# Altium schematic sheet CC2650RC_4MOD1106_periph_part_rev1_2.SchDoc(CC2650RC_4MOD1106_periph_part_rev1_2)
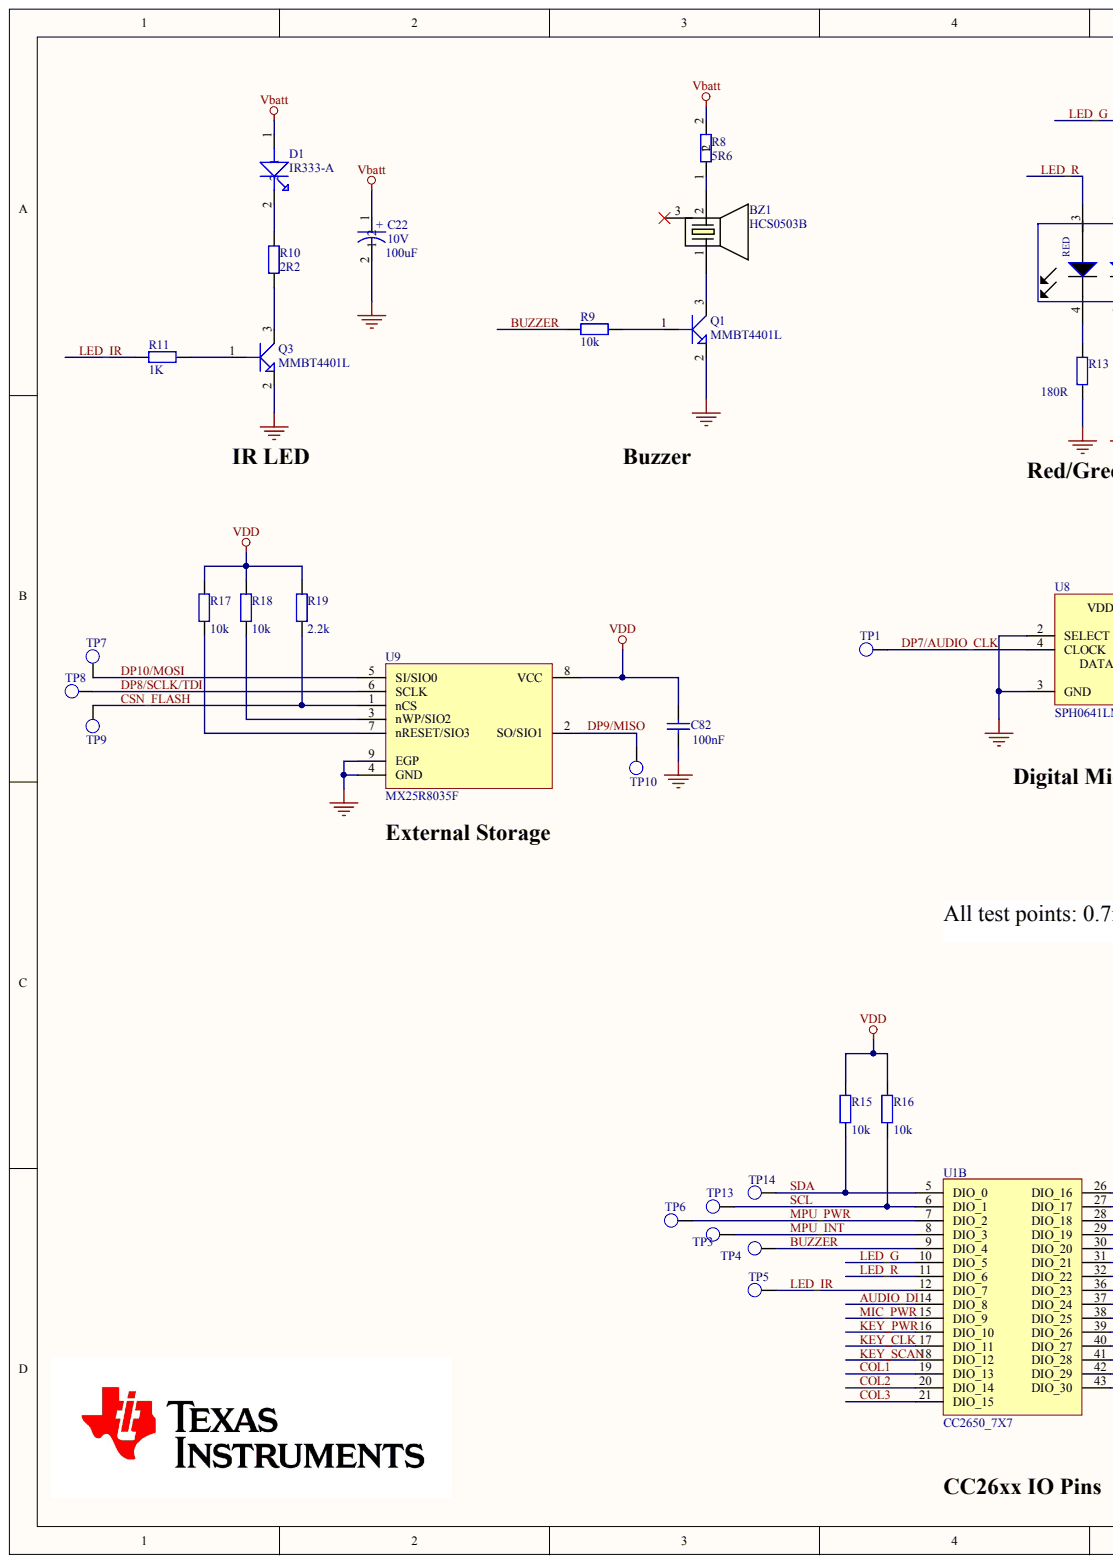
[diagram p0: CC2650RC_4MOD1106_periph_part_rev1_2.SchDoc, left]
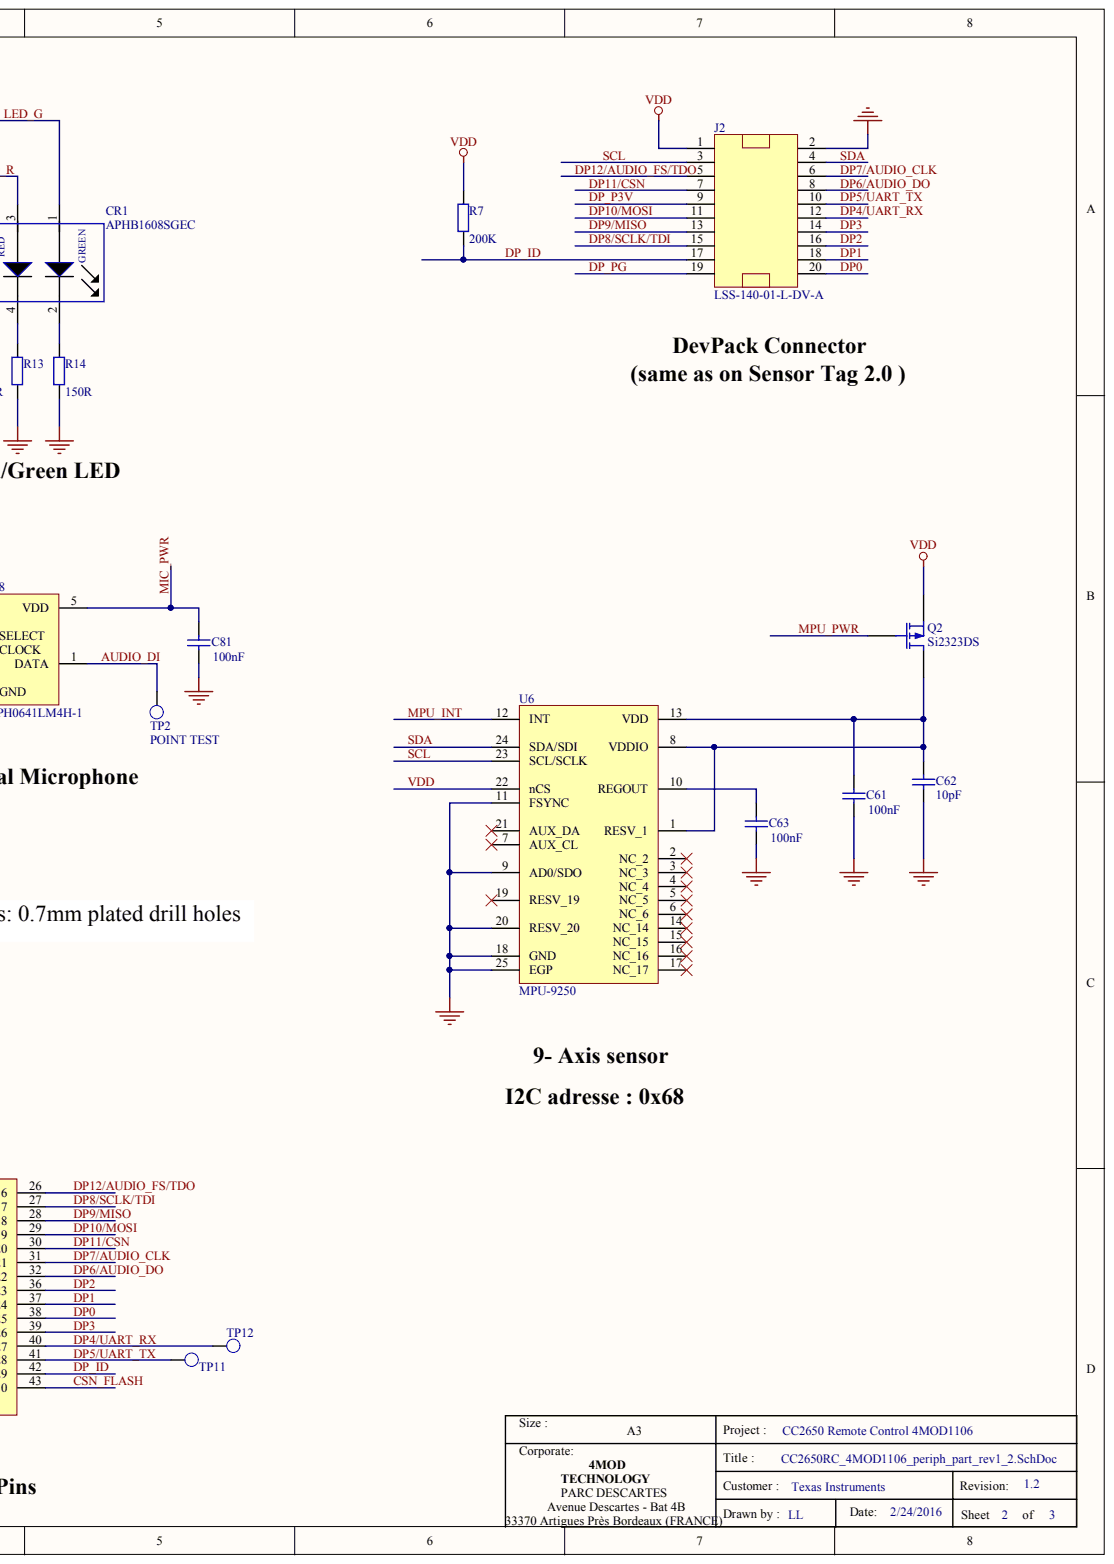
[diagram p1: CC2650RC_4MOD1106_periph_part_rev1_2.SchDoc, right]

## Parts
BZ1  HCS0503B  [BUZZER_HCS0503B]
C22  10V  value 100uF  [CAPA_ELECTRO_SMD_C_SIZE]
C61  value 100nF  [C402_4MOD]
C62  value 10pF  [C402_4MOD]
C63  value 100nF  [C402_4MOD]
C81  value 100nF  [C402_4MOD]
C82  value 100nF  [C402_4MOD]
CR1  APHB1608SGEC  [LED_BICOLOR_SMD_APHB1608]
D1  IR333-A  [IR_LED_5MM_4MOD1106_SMT]
J2  LSS-140-01-L-DV-A  [SAMTEC_LSS-110-01-F-DV-A]
Q1  MMBT4401L  [SOT23-3]
Q2  Si2323DS  [SOT23-3]
Q3  MMBT4401L  [SOT23-3]
R7  200k  [R402-4MOD]
R8  5R6  [R402-4MOD]
R9  10k  [R402-4MOD]
R10  value 2R2  [R1206_4MOD]  (hidden comment: 2.2)
R11  1K  [R402-4MOD]
R13  180R  [R603_4MOD]
R14  150R  [R603_4MOD]
R15  10k  [R402-4MOD]
R16  10k  [R402-4MOD]
R17  10k  [R402-4MOD]
R18  10k  [R402-4MOD]
R19  2.2k  [R402-4MOD]
TP1  TEST POINT  [TP_CC2650RC]  (value not drawn)
TP2  POINT TEST  [TP_CC2650RC]
TP3  POINT TEST  [TP_CC2650RC]
TP4  POINT TEST  [TP_CC2650RC]
TP5  POINT TEST  [TP_CC2650RC]
TP6  POINT TEST  [TP_CC2650RC]
TP7  POINT TEST  [TP_CC2650RC]
TP8  POINT TEST  [TP_CC2650RC]
TP9  POINT TEST  [TP_CC2650RC]
TP10  TEST POINT  [TP_CC2650RC]  (value not drawn)
TP11  POINT TEST  [TP_CC2650RC]
TP12  POINT TEST  [TP_CC2650RC]
TP13  POINT TEST  [TP_CC2650RC]
TP14  POINT TEST  [TP_CC2650RC]
U1  CC2650_7X7  [CC26XX_QFN48(7x7)_0P5]  (part B)
U6  MPU-9250  [QFN24_0P4_3X3MM]
U8  SPH0641LM4H-1  [SPH0641LM4H-1_MEMSMICRO]
U9  MX25R8035F  [W25X40CL_USON-8_(2mmx3mm)]

## Nets
GND: C22.2 C61.1 C62.2 C63.1 C81.1 C82.1 J2.2 Q1.2 Q3.2 R13.2 R14.2 U6.9(AD0/SDO) U6.11(FSYNC) U6.18(GND) U6.20(RESV_20) U6.25(EGP) U8.2(SELECT) U8.3(GND) U9.4(GND) U9.9(EGP)
VBATT: C22.1 D1.1 R8.2
AUDIO_DI: TP2.1 U1.14(DIO_8) U8.1(DATA)
BUZZER: R9.1 TP4.1 U1.9(DIO_4)
COL1: U1.19(DIO_13)
COL2: U1.20(DIO_14)
COL3: U1.21(DIO_15)
CSN_FLASH: R19.2 TP9.1 U1.43(DIO_30) U9.1(nCS)
DP0: J2.20 U1.38(DIO_25)
DP1: J2.18 U1.37(DIO_24)
DP2: J2.16 U1.36(DIO_23)
DP3: J2.14 U1.39(DIO_26)
DP4/UART_RX: J2.12 TP12.1 U1.40(DIO_27)
DP5/UART_TX: J2.10 TP11.1 U1.41(DIO_28)
DP6/AUDIO_DO: J2.8 U1.32(DIO_22)
DP7/AUDIO_CLK: J2.6 TP1.1 U1.31(DIO_21) U8.4(CLOCK)
DP8/SCLK/TDI: J2.15 TP8.1 U1.27(DIO_17) U9.6(SCLK)
DP9/MISO: J2.13 TP10.1 U1.28(DIO_18) U9.2(SO/SIO1)
DP10/MOSI: J2.11 TP7.1 U1.29(DIO_19) U9.5(SI/SIO0)
DP11/CSN: J2.7 U1.30(DIO_20)
DP12/AUDIO_FS/TDO: J2.5 U1.26(DIO_16)
DP_ID: J2.17 R7.2 U1.42(DIO_29)
DP_P3V: J2.9
DP_PG: J2.19
KEY_CLK: U1.17(DIO_11)
KEY_PWR: U1.16(DIO_10)
KEY_SCAN: U1.18(DIO_12)
LED_G: CR1.1 U1.10(DIO_5)
LED_IR: R11.1 TP5.1 U1.12(DIO_7)
LED_R: CR1.3 U1.11(DIO_6)
MIC_PWR: C81.2 U1.15(DIO_9) U8.5(VDD)
MPU_INT: TP3.1 U1.8(DIO_3) U6.12(INT)
MPU_PWR: Q2.1 TP6.1 U1.7(DIO_2)
SCL: J2.3 R16.2 TP13.1 U1.6(DIO_1) U6.23(SCL/SCLK)
SDA: J2.4 R15.2 TP14.1 U1.5(DIO_0) U6.24(SDA/SDI)
VDD: C82.2 J2.1 Q2.2 R7.1 R15.1 R16.1 R17.1 R18.1 R19.1 U6.22(nCS) U9.8(VCC)
NetBZ1_1: BZ1.1 Q1.3
NetBZ1_2: BZ1.2 R8.1
NetC61_2: C61.2 C62.1 Q2.3 U6.1(RESV_1) U6.8(VDDIO) U6.13(VDD)
NetC63_2: C63.2 U6.10(REGOUT)
NetCR1_2: CR1.2 R14.1
NetCR1_4: CR1.4 R13.1
NetD1_2: D1.2 R10.2
NetQ1_1: Q1.1 R9.2
NetQ3_1: Q3.1 R11.2
NetQ3_3: Q3.3 R10.1
NetR17_2: R17.2 U9.7(nRESET/SIO3)
NetR18_2: R18.2 U9.3(nWP/SIO2)

Not connected: BZ1 3; U6 2(NC_2) 3(NC_3) 4(NC_4) 5(NC_5) 6(NC_6) 7(AUX_CL) 14(NC_14) 15(NC_15) 16(NC_16) 17(NC_17) 19(RESV_19) 21(AUX_DA)

## Library data of the parts
BZ1 = BUZZER: Component Kind: Standard; Description: BUZZER; Library Name: 4MOD_PASSIVE.SCHLIB
C22 = CAPACITOR: Electrolytic capacitor , 100 uF , 10V , smd case C size; Component Kind: Standard; Library Name: PASSIF.SCHLIB
C61 C63 C81 C82 = Cap: Capacitor, Ceramic X7R, 100nF, 6.3V, +/- 10%, SMD  case 0402; Component Kind: Standard; LatestRevisionDate: 17-Jul-2002; LatestRevisionNote: Re-released for DXP Platform.; Library Name: Miscellaneous Devices.IntLib; PackageReference: RAD-0.3; Published: 8-Jun-2000; Signal Integrity: Cap; Simulation: CAP; Publisher: Altium Limited
C62 = Cap: Ceramic Capacitor , NPO/COG, 10pF ,50V,  5 %, -55/+125 °C, smd case 0402; Component Kind: Standard; LatestRevisionDate: 17-Jul-2002; LatestRevisionNote: Re-released for DXP Platform.; Library Name: Miscellaneous Devices.IntLib; PackageReference: RAD-0.3; Published: 8-Jun-2000; Signal Integrity: Cap; Simulation: CAP; Publisher: Altium Limited
CR1 = KINGBRIGHT_APHB1608SGEC: APHB1608SGEC  BI-COLOR SURFACE MOUNT  LED, Super Bright Green   High Efficiency Red, Kingbright ,smd case specific ( 1.6x0.8x0.5mm ); Component Kind: Standard; Library Name: 4MOD_PASSIVE.SCHLIB
D1 = LED: OPTO, LED, IR, 940nm, 0.1A, 0.15W, angle radiation = 20°, 5MMdiameter , T1-3/4, Throught Hole; Component Kind: Standard; Library Name: PASSIF.SCHLIB
J2 = SAMTEC_LSS-110-01-F-DV-A-TR: CONNECTOR, HEADER, HI-SPEED SOCKET, FEMALE, STRAIGHT, 2 ROWS,20 PINS, PITCH 0.635mm, SMD case; Component Kind: Standard; Library Name: 4MOD_PASSIVE.SCHLIB
Q1 Q3 = NPN-CMS: Transistor Bipolar NPN , BIPOLAR NPN, 40V, 0.6A, 0.3W, SMD case SOT23-3; Component Kind: Standard; PCB3D: BAS-16; value: MMBT4401L; Library Name: PASSIF.SCHLIB
Q2 = MOSFET-P: Si2323DS, TRANSISTOR, MOSFET P-CHANNEL, -20V, -3.7A, 0.75W, smd case SOT23-3; Component Kind: Standard; LatestRevisionDate: 17-Jul-2002; LatestRevisionNote: Re-released for DXP Platform.; Library Name: Miscellaneous Devices.IntLib; PackageDescription: TO, Flat Index; 3 In-Line, Axial Leads; Body Dia. 4.6mm; Lead Dia. 0.5 mm (max); PackageReference: E3; PackageVersion: 1995/1996; Published: 8-Jun-2000; Simulation: PMOS; Publisher: Altium Limited
R7 = Res2: Resistor, Thick Film, 200k, +/- 5% 50V 0.063W, SMD case 0402; Component Kind: Standard; LatestRevisionDate: 17-Jul-2002; LatestRevisionNote: Re-released for DXP Platform.; Library Name: Miscellaneous Devices.IntLib; PackageReference: AXIAL-0.4; Published: 8-Jun-2000; Signal Integrity: Res; Simulation: RESISTOR; Value: 200K; Publisher: Altium Limited
R8 = Res2: Resistor, Thick Film, 5R6, +/- 5% 50V 0.063W, SMD case 0402; Component Kind: Standard; LatestRevisionDate: 17-Jul-2002; LatestRevisionNote: Re-released for DXP Platform.; Library Name: Miscellaneous Devices.IntLib; PackageReference: AXIAL-0.4; Published: 8-Jun-2000; Signal Integrity: Res; Simulation: RESISTOR; Value: 5R6; Publisher: Altium Limited
R9 R15-R18 = Res2: Resistor, Thick Film, 10k, +/- 5% 50V 0.063W, SMD case 0402; Component Kind: Standard; LatestRevisionDate: 17-Jul-2002; LatestRevisionNote: Re-released for DXP Platform.; Library Name: Miscellaneous Devices.IntLib; PackageReference: AXIAL-0.4; Published: 8-Jun-2000; Signal Integrity: Res; Simulation: RESISTOR; Value: 10k; Publisher: Altium Limited
R10 = Res2: Resistor, Thick Film, 2R2, +/- 5%, 200V ,0.200W, SMD case 1206; Component Kind: Standard; LatestRevisionDate: 17-Jul-2002; LatestRevisionNote: Re-released for DXP Platform.; Library Name: Miscellaneous Devices.IntLib; PackageReference: AXIAL-0.4; Published: 8-Jun-2000; Signal Integrity: Res; Simulation: RESISTOR; Publisher: Altium Limited
R11 = Res2: Resistor, Thick Film, 1k, +/- 1% ,50V ,100mW, SMD case 0402; Component Kind: Standard; LatestRevisionDate: 17-Jul-2002; LatestRevisionNote: Re-released for DXP Platform.; Library Name: Miscellaneous Devices.IntLib; PackageReference: AXIAL-0.4; Published: 8-Jun-2000; Signal Integrity: Res; Simulation: RESISTOR; Value: 1K; Publisher: Altium Limited
R13 = Res2: Resistor, Thick Film, 180R, +/- 5% 75V 0.100W, SMD case 0603; Component Kind: Standard; LatestRevisionDate: 17-Jul-2002; LatestRevisionNote: Re-released for DXP Platform.; Library Name: Miscellaneous Devices.IntLib; PackageReference: AXIAL-0.4; Published: 8-Jun-2000; Signal Integrity: Res; Simulation: RESISTOR; Value: 180R; Publisher: Altium Limited
R14 = Res2: Resistor, Thick Film, 150R, +/- 5% 75V 0.100W, SMD case 0603; Component Kind: Standard; LatestRevisionDate: 17-Jul-2002; LatestRevisionNote: Re-released for DXP Platform.; Library Name: Miscellaneous Devices.IntLib; PackageReference: AXIAL-0.4; Published: 8-Jun-2000; Signal Integrity: Res; Simulation: RESISTOR; Value: 150R; Publisher: Altium Limited
R19 = Res2: Resistor, Thick Film, 2.2k +/- 5% 50V 0.063W, SMD case 0402; Component Kind: Standard; LatestRevisionDate: 17-Jul-2002; LatestRevisionNote: Re-released for DXP Platform.; Library Name: Miscellaneous Devices.IntLib; PackageReference: AXIAL-0.4; Published: 8-Jun-2000; Signal Integrity: Res; Simulation: RESISTOR; Value: 2.2k; Publisher: Altium Limited
TP1 TP10 = POINT TEST: Component Kind: Standard; Description: Point test; PCB3D: HDR1x1; Library Name: PASSIF.SCHLIB
U1 = CC2650_7X7: CC2650, SimpleLink multi-standard 2.4 GHz ultra-low power wireless MCU, Texas Instruments , smd case VQFN48 ( 7mmx7mm); Component Kind: Standard; Library Name: 4MOD_Devices.SCHLIB
U6 = MPU-9250: MPU-9250, GYRO/ACCEL/COMPASS/9-AXIS, InvenSense, 0.4mm pitch , SMD case QFN24(3mm*3mm); Component Kind: Standard; Library Name: 4MOD_Devices.SCHLIB
U8 = SPH0641LM4H-1: SPH0641LM4H-1, Digital Zero-Height SiSonic Microphone  With Multiple Performance Modes, Input Bottom, Knowles; smd case specific; Component Kind: Standard; Library Name: 4MOD_Devices.SCHLIB
U9 = MX25R8035F: MX25R8035F, ULTRA LOW POWER, 8M-BIT [x 1/x 2/x 4]  CMOS MXSMIO® (SERIAL MULTI I/O)  FLASH MEMORY, smd case USON8; Component Kind: Standard; Library Name: 4MOD_Devices.SCHLIB
TP2-TP9 TP11-TP14 = POINT TEST: Component Kind: Standard; Description: Point test; PCB3D: HDR1x1; Library Name: PASSIF.SCHLIB
